# Altium schematic sheet main_sheet.SchDoc
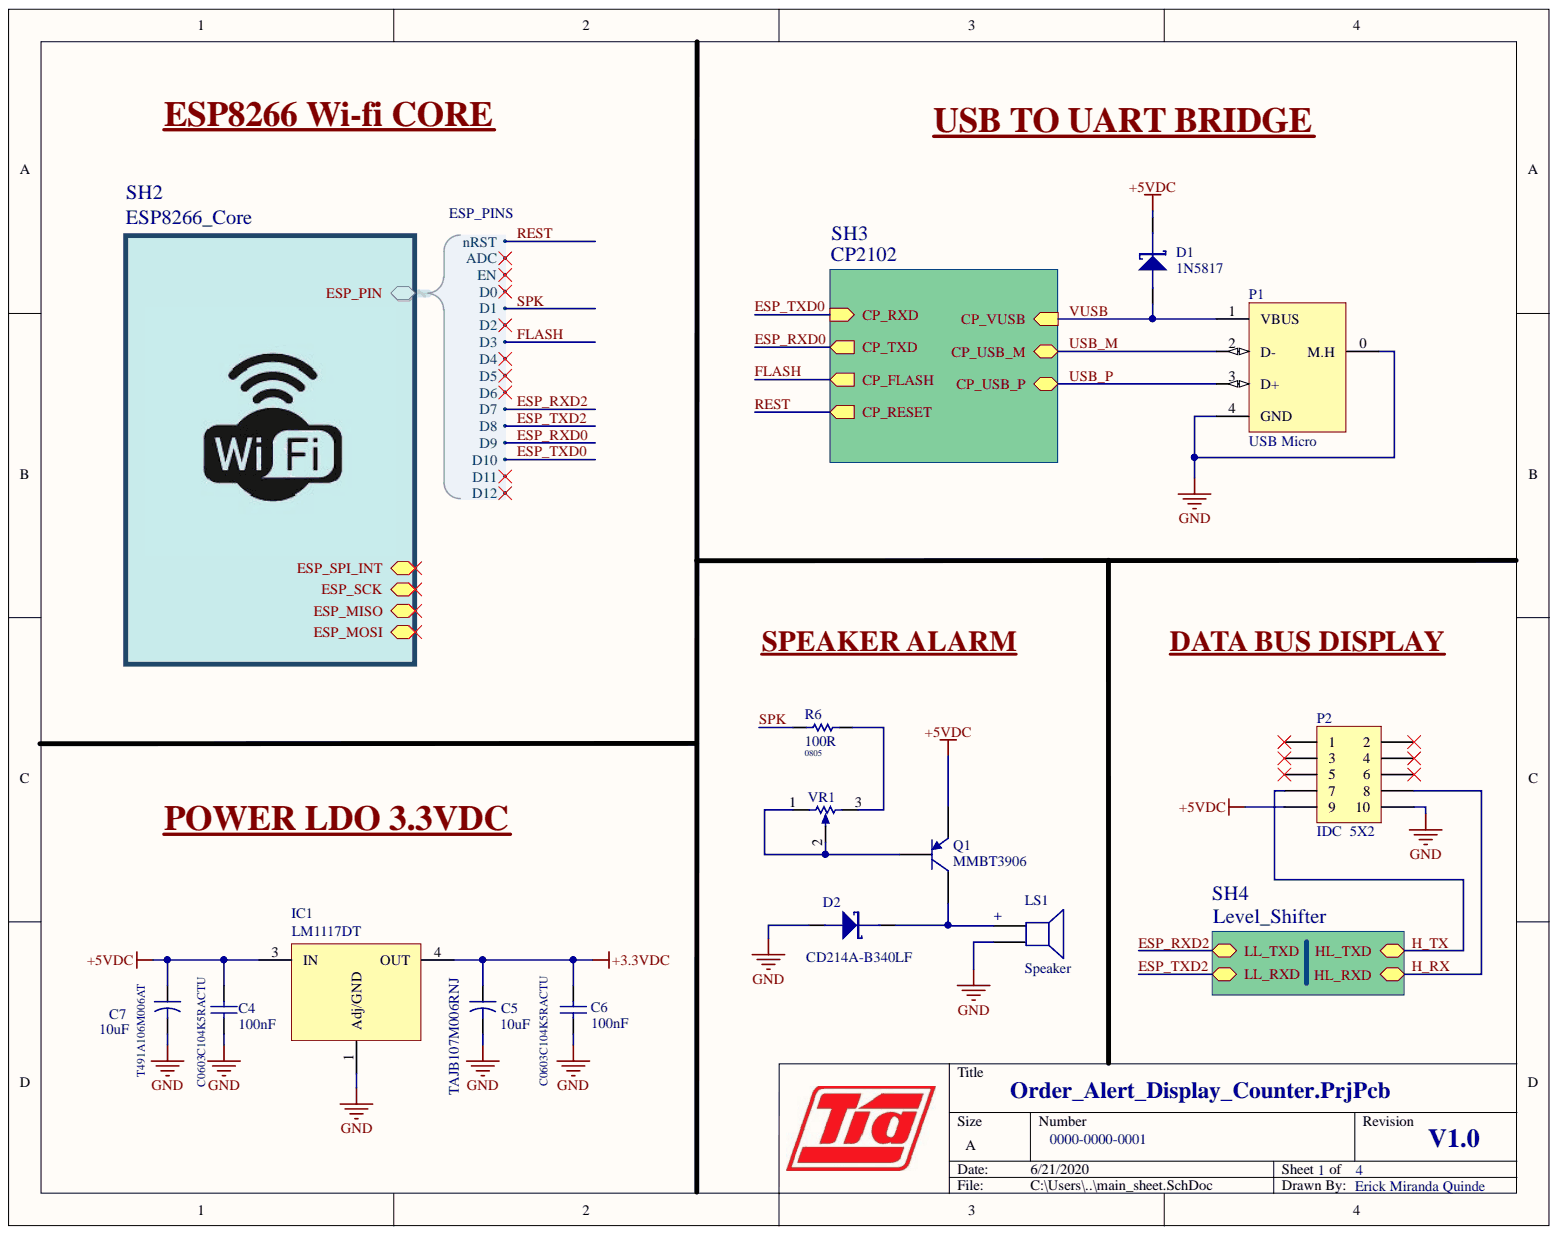
[diagram p0: main_sheet.SchDoc]

## Parts
C4  100nF  [C0603C104K5RACTU]
C5  TAJB107M006RNJ  value 10uF  [TAJB107M006RNJ]
C6  C0603C104K5RACTU  value 100nF  [C0603C104K5RACTU]
C7  T491A106M006AT  value 10uF  [3216 Case-A]
D1  1N5817  [Diode S4]
D2  CD214A-B340LF  [DO-214AC)]
IC1  LM1117DT  [TO252]
LS1  Speaker  [VERDE 2pin]
P1  USB Micro  [USB_MICRO_B_AT]
P2  IDC  5X2  [IDC5X2]
Q1  MMBT3906  [SOT-23-TT3_N]
R6  100R  Package: 0805  [RES-0805]
VR1  Trimmer Cermet 104  [POT LIN VR1]  (value not drawn)

## Nets
+3.3VDC: C5.1 C6.2 IC1.4
+5VDC: C4.2 C7.1 D1.1 IC1.3 P2.9 Q1.2
GND: C4.1 C5.2 C6.1 C7.2 D2.2 IC1.1 LS1.2 P1.0(M.H) P1.4(GND) P2.10
H_RX: P2.8
H_TX: P2.7
SPK: R6.1
USB_M: P1.2(D-)
USB_P: P1.3(D+)
VUSB: D1.2 P1.1(VBUS)
NetD2_1: D2.1 LS1.1 Q1.3
NetP2_1: P2.1
NetP2_2: P2.2
NetP2_3: P2.3
NetP2_4: P2.4
NetP2_5: P2.5
NetP2_6: P2.6
NetQ1_1: Q1.1 VR1.1 VR1.2
NetR6_2: R6.2 VR1.3
ESP_MISO:
ESP_MOSI:
ESP_PIN.ADC:
ESP_PIN.D0:
ESP_PIN.D2:
ESP_PIN.D4:
ESP_PIN.D5:
ESP_PIN.D6:
ESP_PIN.D11:
ESP_PIN.D12:
ESP_PIN.EN:
ESP_RXD0:
ESP_RXD2:
ESP_SCK:
ESP_SPI_INT:
ESP_TXD0:
ESP_TXD2:
FLASH:
REST:

## Library data of the parts
C4 C6 = Cap 100nF (0603): Component Kind: Standard; PART_NUM: C0603C104K5RACTU; Library Name: IDETEC LIB.IntLib
  C4: Value: 100nF
C5 = Cap 10uF (C3216): Capacitor; Component Kind: Standard; Library Name: IDETEC LIB.IntLib
C7 = T491A106M006AT: CAP TANT 10UF 6.3V 20% 1206; Component Kind: Standard; Library Name: IDETEC LIB.IntLib
D2 = CD214A-B340LF: DIODE SCHOTTKY 40V 3A SMA; Component Kind: Standard; Library Name: IDETEC LIB.IntLib
IC1 = LM1117DT: 800mA Low-Dropout Linear Regulator; Manufacturer: National Semiconductor; Component Kind: Standard; Datasheet: http://www.national.com/ds/LM/LM1117.pdf; Library Name: TSK Library.IntLib
LS1 = Speaker: Loudspeaker; Component Kind: Standard; LatestRevisionDate: 17-Jul-2002; LatestRevisionNote: Re-released for DXP Platform.; PackageReference: PIN2; Published: 24-Mar-1999; Library Name: IDETEC LIB.IntLib; Publisher: Altium Limited
P1 = USB Mini: Component Kind: Standard; Description: USB Mini; Library Name: IDETEC LIB.IntLib
P2 = IDC  5X2: Header, 5-Pin, Dual row; Component Kind: Standard; LatestRevisionDate: 17-Jul-2002; LatestRevisionNote: Re-released for DXP Platform.; Library Name: IDETEC LIB.IntLib; Publisher: Altium Limited
Q1 = 2N3906: Manufacturer: Micro Commercial Co; Manufacturer Part Number: 2N3906-AP; Current - Collector (Ic) (Max): 200mA; DC Current Gain (hFE) (Min) @ Ic, Vce: 100 @ 10mA, 1V; Frequency - Transition: 250MHz; Power - Max: 600mW; Transistor Type: PNP; Vce Saturation (Max) @ Ib, Ic: 400mV @ 5mA, 50mA; Voltage - Collector Emitter Breakdown (Max): 40V; Category: Discrete Semiconductor Products; Component Kind: Standard; ComponentLink1Description: http://www.mccsemi.com/up_pdf/2N3906(TO-92).pdf; ComponentLink1URL: http://www.mccsemi.com/up_pdf/2N3906(TO-92).pdf; Current - Collector Cutoff (Max): -; Mounting Type: Through Hole; Package / Case: TO-226-3, TO-92-3 (TO-226AA) Formed Leads; Packaging: Tape & Box (TB); Pricing 1: 2000=0.0306, 6000=0.0276, 10000=0.024, 50000=0.0192, 100000=0.018, 200000=0.01596 (USD); RoHS: RoHS Compliant; Stock 1: 54000; Supplier 1: Digi-Key; Supplier Device Package: TO-92; Supplier Part Number 1: 2N3906-APTB-ND; Library Name: IDETEC LIB.IntLib
R6 = Resistor: Component Kind: Standard; Description: Resistor; LatestRevisionDate: 17-Jul-2002; LatestRevisionNote: Re-released for DXP Platform.; PackageDescription: Axial Device, Thru-Hole; 2 Leads; 0.3 in Pin Spacing; PackageReference: AXIAL-0.3; Published: 8-Jun-2000; Signal Integrity: Res; Simulation: RESISTOR; Value: 100R; Library Name: IDETEC LIB.IntLib; Publisher: Altium Limited
D1 = 1N5817: Component Kind: Standard; Library Name: IDETEC LIB.IntLib
VR1 = Trimmer Cermet 104: Component Kind: Standard; Description: Trimmer Cermet 104; Library Name: IDETEC LIB.IntLib
